# Altium schematic sheet MotorTreiber.SchDoc
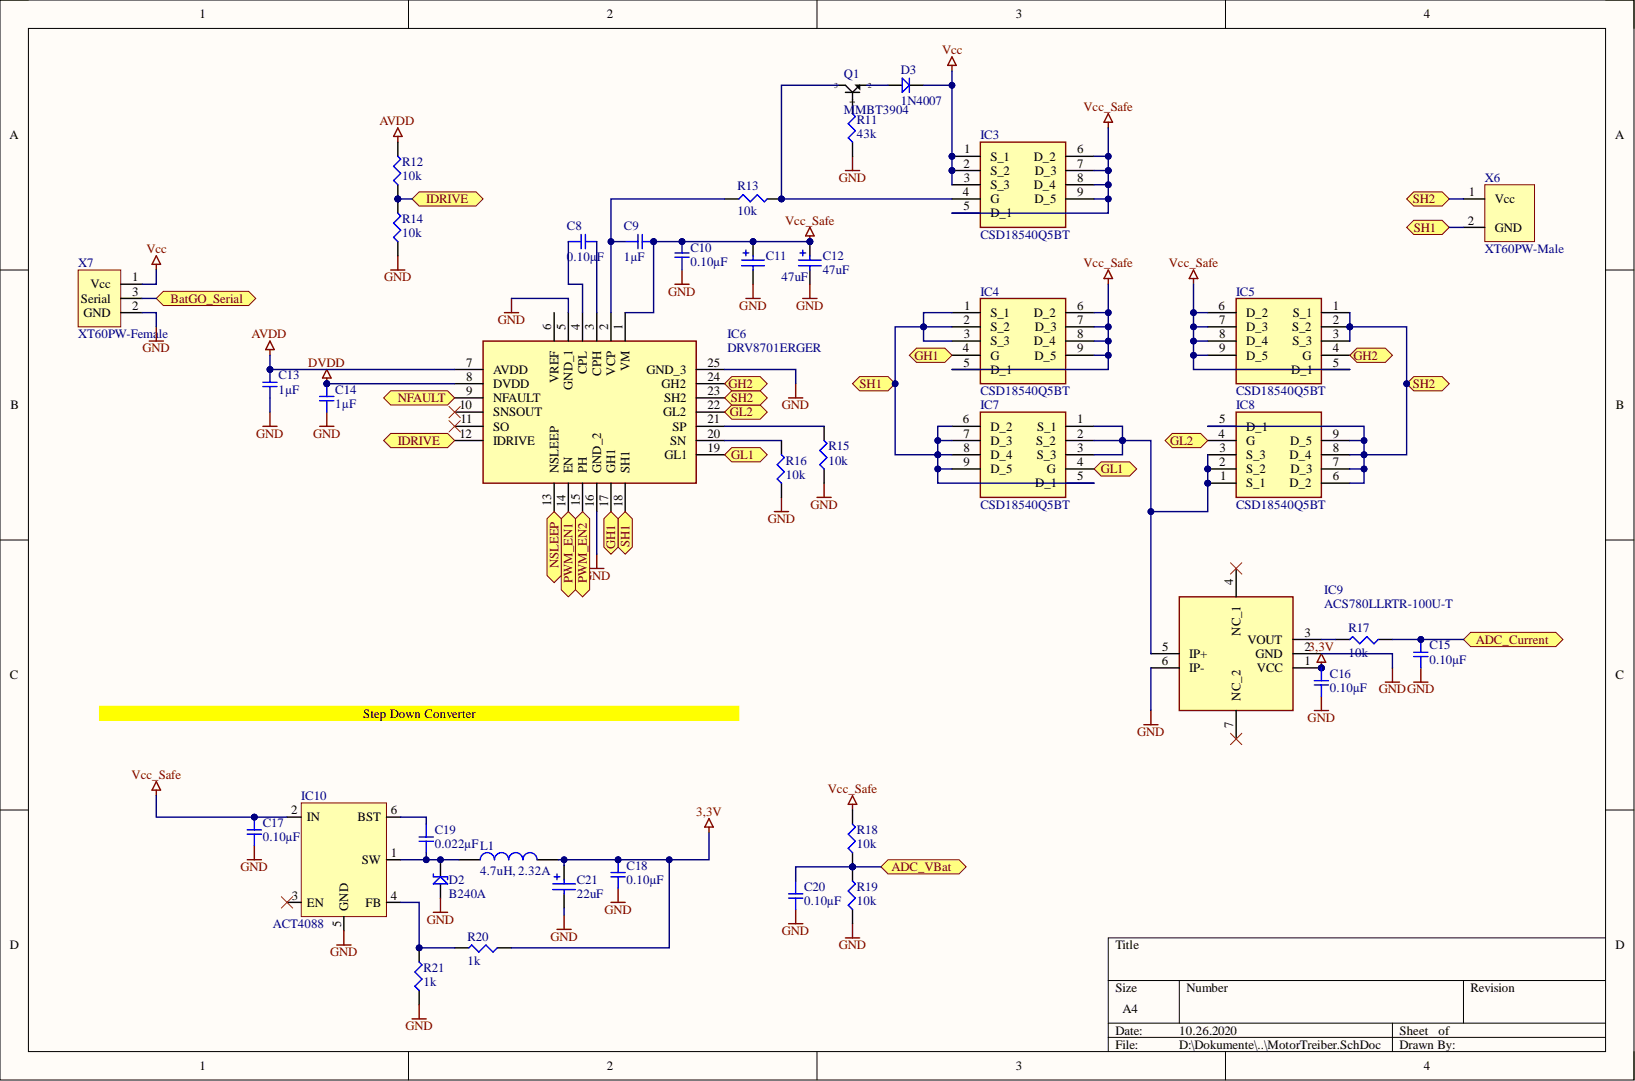
[diagram p0: MotorTreiber.SchDoc]

## Parts
C8  0.10µF  [SMD-0402C]
C9  1µF  [SMD-0402C]
C10  0.10µF  [SMD-0402C]
C11  value 47uF  [WCAP-ASLI_6.3x7.7]  (hidden comment: 865080645012)
C12  value 47uF  [WCAP-ASLI_6.3x7.7]  (hidden comment: 865080645012)
C13  1µF  [SMD-0402C]
C14  1µF  [SMD-0402C]
C15  0.10µF  [SMD-0402C]
C16  0.10µF  [SMD-0402C]
C17  0.10µF  [SMD-0402C]
C18  0.10µF  [SMD-0402C]
C19  0.022µF  [SMD-0603C]
C20  0.10µF  [SMD-0402C]
C21  value 22uF  [WCAP-ASLI_4x5.5]  (hidden comment: 865080140001)
D2  B240A  [B240A]
D3  1N4007  [SOD-323]
IC3  CSD18540Q5BT  [CSD18540Q5BT]
IC4  CSD18540Q5BT  [CSD18540Q5BT]
IC5  CSD18540Q5BT  [CSD18540Q5BT]
IC6  DRV8701ERGER  [QFN50P400X400X100-25N-D]
IC7  CSD18540Q5BT  [CSD18540Q5BT]
IC8  CSD18540Q5BT  [CSD18540Q5BT]
IC9  ACS780LLRTR-100U-T  [7-PIN_PSOF]
IC10  ACT4088  [SOT23-6]
L1  4.7uH, 2.32A  Rated Current: 2.32A  [WE-PD_7332]
Q1  MMBT3904  [SOT23]
R11  43k  [SMD-0402-RES]
R12  10k  [SMD-0402-RES]
R13  10k  [SMD-0402-RES]
R14  10k  [SMD-0402-RES]
R15  10k  [SMD-0402-RES]
R16  10k  [SMD-0402-RES]
R17  10k  [SMD-0402-RES]
R18  10k  [SMD-0402-RES]
R19  10k  [SMD-0402-RES]
R20  1k  [SMD-0603-RES]
R21  1k  [SMD-0603-RES]
X6  XT60PW-Male  [XT60PW_Male]
X7  XT60PW-Female  [XT60PWI-M_Female]

## Ports
ADC_Current, ADC_VBat, BatGO_Serial, GH1, GH2, GL1, GL2, IDRIVE, NFAULT, NSLEEP, PWM_EN1, PWM_EN2, SH1, SH2

## Nets
3,3V: C16.1 C18.1 C21.1 IC9.1(VCC) L1.2 R20.1
AVDD: C13.1 IC6.7(AVDD) R12.2
DVDD: C14.1 IC6.8(DVDD)
GND: C10.1 C11.2 C12.2 C13.2 C14.2 C15.2 C16.2 C17.2 C18.2 C20.2 C21.2 D2.A IC6.5(GND_1) IC6.16(GND_2) IC6.25(GND_3) IC9.2(GND) IC9.6(IP-) IC10.5(GND) R11.1 R14.1 R15.1 R16.1 R19.1 R21.1 X7.2
Vcc: D3.K IC3.1(S_1) IC3.2(S_2) IC3.3(S_3) X7.1
Vcc_Safe: C9.2 C10.2 C11.1 C12.1 C17.1 IC3.5(D_1) IC3.6(D_2) IC3.7(D_3) IC3.8(D_4) IC3.9(D_5) IC4.5(D_1) IC4.6(D_2) IC4.7(D_3) IC4.8(D_4) IC4.9(D_5) IC5.5(D_1) IC5.6(D_2) IC5.7(D_3) IC5.8(D_4) IC5.9(D_5) IC6.1(VM) IC10.2(IN) R18.2
ADC_Current: C15.1 R17.1 ; port ADC_Current
ADC_VBat: C20.1 R18.1 R19.2 ; port ADC_VBat
BatGO_Serial: X7.3 ; port BatGO_Serial
GH1: IC4.4(G) IC6.17(GH1) ; port GH1
GH2: IC5.4(G) IC6.24(GH2) ; port GH2
GL1: IC6.19(GL1) IC7.4(G) ; port GL1
GL2: IC6.22(GL2) IC8.4(G) ; port GL2
IDRIVE: IC6.12(IDRIVE) R12.1 R14.2 ; port IDRIVE
NFAULT: IC6.9(NFAULT) ; port NFAULT
NSLEEP: IC6.13(NSLEEP) ; port NSLEEP
PWM_EN1: IC6.14(EN) ; port PWM_EN1
PWM_EN2: IC6.15(PH) ; port PWM_EN2
SH1: IC4.1(S_1) IC4.2(S_2) IC4.3(S_3) IC6.18(SH1) IC7.5(D_1) IC7.6(D_2) IC7.7(D_3) IC7.8(D_4) IC7.9(D_5) X6.2 ; port SH1
SH2: IC5.1(S_1) IC5.2(S_2) IC5.3(S_3) IC6.23(SH2) IC8.5(D_1) IC8.6(D_2) IC8.7(D_3) IC8.8(D_4) IC8.9(D_5) X6.1 ; port SH2
NetC8_1: C8.1 IC6.4(CPL)
NetC8_2: C8.2 IC6.3(CPH)
NetC9_1: C9.1 IC6.2(VCP) R13.1
NetC19_1: C19.1 IC10.6(BST)
NetC19_2: C19.2 D2.K IC10.1(SW) L1.1
NetD3_A: D3.A Q1.S
NetIC3_4: IC3.4(G) Q1.D R13.2
NetIC6_20: IC6.20(SN) R16.2
NetIC6_21: IC6.21(SP) R15.2
NetIC7_1: IC7.1(S_1) IC7.2(S_2) IC7.3(S_3) IC8.1(S_1) IC8.2(S_2) IC8.3(S_3) IC9.5(IP+)
NetIC9_3: IC9.3(VOUT) R17.2
NetIC10_4: IC10.4(FB) R20.2 R21.2
NetQ1_G: Q1.G R11.2

Not connected: IC6 6(VREF) 10(SNSOUT) 11(SO); IC9 4(NC_1) 7(NC_2); IC10 3(EN)

## Library data of the parts
C8 C10 C15-C18 C20 = GCM155R71C104KA55D: CAP CER 0.1UF 16V X7R 0402; Manufacturer: Murata Electronics North America; @ qty: 0; Minimum Quantity: 1; Tolerance: ±10%; Unit Price (USD): 0.1; Applications: Automotive; Component Kind: Standard; Datasheets: http://www.murata.com/~/media/webrenewal/support/library/catalog/products/capacitor/mlcc/c03e.ashx; Digi-Key Part Number: 490-4759-1-ND; Factory Stock: 0; Features: -; Height - Seated (Max): -; Image: //media.digikey.com/Renders/Murata%20Renders/ZRB-SERIES-0402.jpg; Lead Spacing: -; Lead Style: -; Library Name: capacitor_murata_0402.IntLib; Mounting Type: Surface Mount, MLCC; Operating Temperature: -55°C ~ 125°C; Package / Case: 0402 (1005 Metric); Packaging: Cut Tape (CT); Part Number: GCM155R71C104KA55D; Part Status: Active; Quantity Available: 734194; Ratings: AEC-Q200; Series: GCM; Size / Dimension: 0.039" L x 0.020" W (1.00mm x 0.50mm); Temperature Coefficient: X7R; Thickness (Max): 0.022" (0.55mm); Value: 0.10µF; Voltage: 16V
C9 C13 C14 = GRM155C80J105KE02D: CAP CER 1UF 6.3V X6S 0402; Manufacturer: Murata Electronics North America; @ qty: 0; Minimum Quantity: 1; Tolerance: ±10%; Unit Price (USD): 0.1; Applications: General Purpose; Component Kind: Standard; Datasheets: http://www.murata.com/~/media/webrenewal/support/library/catalog/products/capacitor/mlcc/c02e.pdf; Digi-Key Part Number: 490-10433-1-ND; Factory Stock: 0; Features: Derating Recommended; Height - Seated (Max): -; Image: //media.digikey.com/Renders/Murata%20Renders/ZRB-SERIES-0402.jpg; Lead Spacing: -; Lead Style: -; Library Name: capacitor_murata_0402.IntLib; Mounting Type: Surface Mount, MLCC; Operating Temperature: -55°C ~ 105°C; Package / Case: 0402 (1005 Metric); Packaging: Cut Tape (CT); Part Number: GRM155C80J105KE02D; Part Status: Active; Quantity Available: 34510; Ratings: -; Series: GRM; Size / Dimension: 0.039" L x 0.020" W (1.00mm x 0.50mm); Temperature Coefficient: X6S; Thickness (Max): 0.022" (0.55mm); Value: 1µF; Voltage: 6.3V
C11 C12 = 865080645012: WCAP-ASLI Aluminum Electrolytic Capacitors, size Ф6.3x7.7mm, 50V DC, 47uF; Rated Voltage: 50V DC; Component Kind: Standard; ComponentLink1Description: Manufacturer; ComponentLink1URL: http://www.we-online.de; ComponentLink2Description: Datasheet; ComponentLink2URL: http://katalog.we-online.de/pbs/datasheet/865080645012.pdf; Library Name: ALTIUM-WCAP-ASLI-rev17a.IntLib; Life Cycle: 2000h; Operating Temperature: -55 °C up to +105 °C; Part Number: 865080645012; Published Date: June 2017; Size: Ф6.3x7.7mm; Publisher: Wurth Elektronik
  C11: Value: 47uF
C19 = GCJ188R71H223KA01D: CAP CER 0.022UF 50V X7R 0603; Manufacturer: Murata Electronics North America; @ qty: 0; Minimum Quantity: 1; Tolerance: ±10%; Unit Price (USD): 0.22; Applications: Automotive, Boardflex Sensitive; Component Kind: Standard; Datasheets: http://www.murata.com/~/media/webrenewal/support/library/catalog/products/capacitor/mlcc/c03e.ashx; Digi-Key Part Number: 490-5851-1-ND; Factory Stock: 0; Features: Soft Termination; Height - Seated (Max): -; Image: //media.digikey.com/Renders/Murata%20Renders/0603%28LQG18%29.jpg; Lead Spacing: -; Lead Style: -; Library Name: capacitor_murata_0603.IntLib; Mounting Type: Surface Mount, MLCC; Operating Temperature: -55°C ~ 125°C; Package / Case: 0603 (1608 Metric); Packaging: Cut Tape (CT); Part Number: GCJ188R71H223KA01D; Part Status: Active; Quantity Available: 7651; Ratings: AEC-Q200; Series: GCJ; Size / Dimension: 0.063" L x 0.031" W (1.60mm x 0.80mm); Temperature Coefficient: X7R; Thickness (Max): 0.031" (0.80mm); Value: 0.022µF; Voltage: 50V
C21 = 865080140001: WCAP-ASLI Aluminum Electrolytic Capacitors, size Ф4x5.5mm, 6.3V DC, 22uF; Rated Voltage: 6.3V DC; Component Kind: Standard; ComponentLink1Description: Manufacturer; ComponentLink1URL: http://www.we-online.de; ComponentLink2Description: Datasheet; ComponentLink2URL: http://katalog.we-online.de/pbs/datasheet/865080140001.pdf; Library Name: ALTIUM-WCAP-ASLI-rev17a.IntLib; Life Cycle: 2000h; Operating Temperature: -55 °C up to +105 °C; Part Number: 865080140001; Published Date: June 2017; Size: Ф4x5.5mm; Publisher: Wurth Elektronik
D2 = B240A: Shottkydiode; Component Kind: Standard; Library Name: MyLibs.SchLib
IC3-IC5 IC7 IC8 = CSD18540Q5BT: Integrated Circuit; Manufacturer_Name: Texas Instruments; Arrow Part Number: CSD18540Q5BT; Arrow Price/Stock: https://www.arrow.com/en/products/csd18540q5bt/texas-instruments; Component Kind: Standard; Datasheet Link: http://www.ti.com/lit/gpn/CSD18540Q5B; Height: 1.05mm; Library Name: MyLibs.SchLib; Manufacturer_Part_Number: CSD18540Q5BT; Mouser Part Number: 595-CSD18540Q5BT; Mouser Price/Stock: https://www.mouser.co.uk/ProductDetail/Texas-Instruments/CSD18540Q5BT?qs=NRIcxvoDUteGYlfdfF0DmQ%3D%3D
IC6 = DRV8701ERGER: Integrated Circuit; Manufacturer_Name: Texas Instruments; Arrow Part Number: DRV8701ERGER; Arrow Price/Stock: https://www.arrow.com/en/products/drv8701erger/texas-instruments; Component Kind: Standard; Datasheet Link: http://www.ti.com/lit/gpn/DRV8701; Height: 1mm; Library Name: MyLibs.SchLib; Manufacturer_Part_Number: DRV8701ERGER; Mouser Part Number: 595-DRV8701ERGER; Mouser Price/Stock: https://www.mouser.co.uk/ProductDetail/Texas-Instruments/DRV8701ERGER?qs=UfUFg%2FkmHHGO0gqJCiEBBQ%3D%3D
IC9 = ACS780LLRTR-100U-T: Integrated Circuit; Manufacturer_Name: Allegro Microsystems; Arrow Part Number: ACS780LLRTR-100U-T; Arrow Price/Stock: https://www.arrow.com/en/products/acs780llrtr-100u-t/allegro-microsystems; Component Kind: Standard; Datasheet Link: https://www.allegromicro.com/~/media/Files/Datasheets/ACS780-Datasheet.ashx; Library Name: MyLibs.SchLib; Manufacturer_Part_Number: ACS780LLRTR-100U-T
L1 = 744778004: WE-PD SMT Power Inductor, size 7332, 4.7uH, 2.32A; DC Resistance max.: 60mOhm; Saturation Current: 4.2A; Self Resonant Frequency: 45MHz; Component Kind: Standard; ComponentLink1Description: Manufacturer; ComponentLink1URL: http://www.we-online.de; ComponentLink2Description: Datasheet; ComponentLink2URL: https://katalog.we-online.com/pbs/datasheet/744778004.pdf; Inductance: 4.7uH; Library Name: ALTIUM-WE-PD-rev19b.IntLib; Mounting Technology: SMT; Operating Temperature: -40 to +125degC; Packaging: Tape and Reel; Part Number: 744778004; Published Date: April 2019; Size: 7332; Publisher: Wurth Elektronik
R11 = ERJ-2GEJ433X: RES SMD 43K OHM 5% 1/10W 0402; Manufacturer: Panasonic Electronic Components; @ qty: 1500; Factory Stock: 0; Minimum Quantity: 1; Power (Watts): 0.1W, 1/10W; Temperature Coefficient: ±200ppm/°C; Unit Price (USD): 0.00350; Component Kind: Standard; Composition: Thick Film; Datasheets: http://industrial.panasonic.com/www-cgi/jvcr13pz.cgi?E+PZ+3+AOA0001+ERJ2GEJ433X+7+WW; Digi-Key Part Number: P43KJCT-ND; Failure Rate: -; Features: Automotive AEC-Q200; Height - Seated (Max): 0.016" (0.40mm); Image: //media.digikey.com/Photos/Panasonic%20Photos/ERJ-PA2-Series.jpg; Library Name: Panasonic_ERJ_0402.IntLib; Number of Terminations: 2; Operating Temperature: -55°C ~ 155°C; Package / Case: 0402 (1005 Metric); Packaging: Cut Tape (CT); Part Number: ERJ-2GEJ433X; Part Status: Active; Quantity Available: 117636; Series: ERJ; Size / Dimension: 0.039" L x 0.020" W (1.00mm x 0.50mm); Supplier Device Package: 0402; Tolerance: ±5%; Value: 43k
R12-R19 = ERJ-2GEJ103X: RES SMD 10K OHM 5% 1/10W 0402; Manufacturer: Panasonic Electronic Components; @ qty: 1500; Factory Stock: 0; Minimum Quantity: 1; Power (Watts): 0.1W, 1/10W; Temperature Coefficient: ±200ppm/°C; Unit Price (USD): 0.00350; Component Kind: Standard; Composition: Thick Film; Datasheets: http://industrial.panasonic.com/www-cgi/jvcr13pz.cgi?E+PZ+3+AOA0001+ERJ2GEJ103X+7+WW; Digi-Key Part Number: P10KJCT-ND; Failure Rate: -; Features: Automotive AEC-Q200; Height - Seated (Max): 0.016" (0.40mm); Image: //media.digikey.com/Photos/Panasonic%20Photos/ERJ-PA2-Series.jpg; Library Name: Panasonic_ERJ_0402.IntLib; Number of Terminations: 2; Operating Temperature: -55°C ~ 155°C; Package / Case: 0402 (1005 Metric); Packaging: Cut Tape (CT); Part Number: ERJ-2GEJ103X; Part Status: Active; Quantity Available: 2560215; Series: ERJ; Size / Dimension: 0.039" L x 0.020" W (1.00mm x 0.50mm); Supplier Device Package: 0402; Tolerance: ±5%; Value: 10k
R20 R21 = ERJ-3EKF1001V: RES SMD 1K OHM 1% 1/10W 0603; Manufacturer: Panasonic Electronic Components; @ qty: 1500; Factory Stock: 0; Minimum Quantity: 1; Power (Watts): 0.1W, 1/10W; Temperature Coefficient: ±100ppm/°C; Unit Price (USD): 0.00379; Component Kind: Standard; Composition: Thick Film; Datasheets: http://industrial.panasonic.com/www-cgi/jvcr13pz.cgi?E+PZ+3+AOA0002+ERJ3EKF1001V+7+WW; Digi-Key Part Number: P1.00KHCT-ND; Failure Rate: -; Features: Automotive AEC-Q200; Height - Seated (Max): 0.022" (0.55mm); Image: //media.digikey.com/Photos/Panasonic%20Photos/MFG_ERJ-P6W%20Series.jpg; Library Name: Panasonic_ERJ_0603.IntLib; Number of Terminations: 2; Operating Temperature: -55°C ~ 155°C; Package / Case: 0603 (1608 Metric); Packaging: Cut Tape (CT); Part Number: ERJ-3EKF1001V; Part Status: Active; Quantity Available: 5218845; Series: ERJ; Size / Dimension: 0.063" L x 0.031" W (1.60mm x 0.80mm); Supplier Device Package: 0603; Tolerance: ±1%; Value: 1k
D3 = 1N4007: Component Kind: Standard; Library Name: MyLib.IntLib
IC10 = ACT4088: Component Kind: Standard; Library Name: MyLibs.SchLib
Q1 = MMBT3904: Component Kind: Standard; Library Name: MyLib.IntLib
X6 = XT60PW-Male: Component Kind: Standard; Library Name: MyLib.IntLib
X7 = XT60PW-Female: Component Kind: Standard; Library Name: MyLib.IntLib
